# Altium schematic sheet water_quality_sensor_hub.SchDoc(water_quality_sensor_hub)
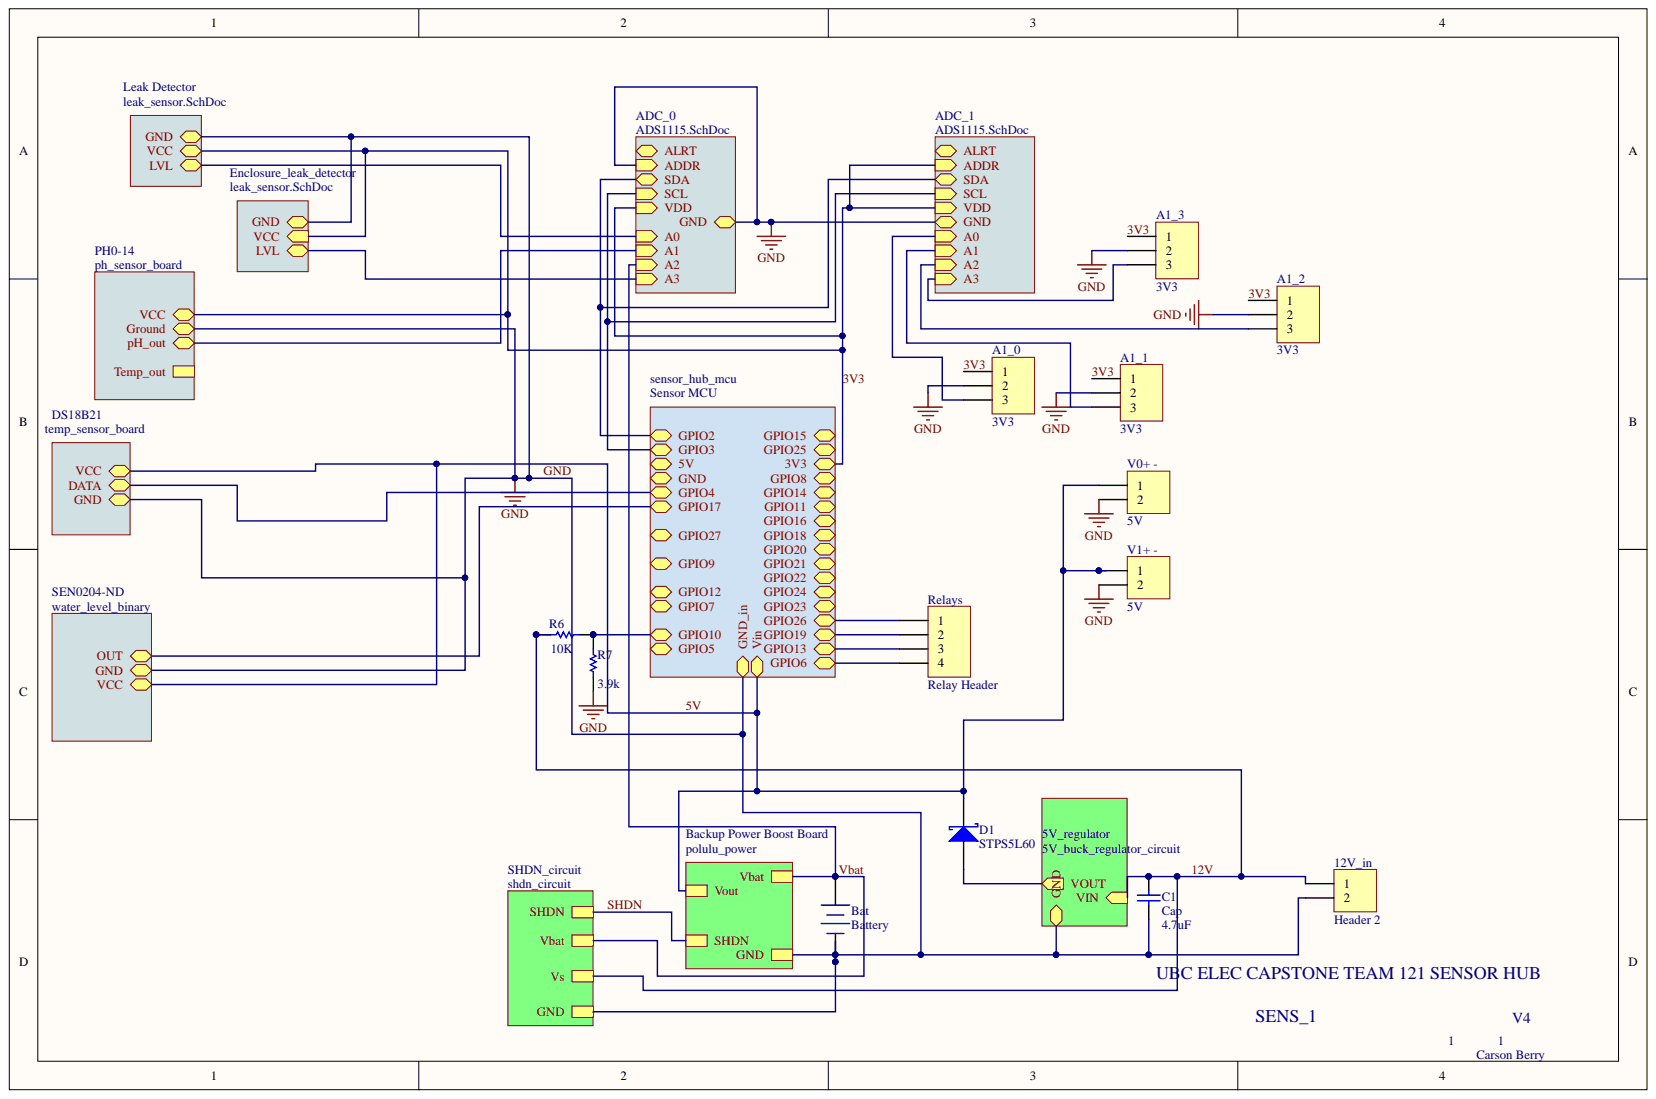
[diagram p0: water_quality_sensor_hub.SchDoc]

## Parts
12V_in  Header 2  [2_channel_terminal_block]
A1_0  3V3  [3_channel_terminal_block]
A1_1  3V3  [3_channel_terminal_block]
A1_2  3V3  [3_channel_terminal_block]
A1_3  3V3  [3_channel_terminal_block]
Bat  Battery  [BAT-2]
C1  Cap  value 4.7uF  [RAD-0.3]
D1  STPS5L60  [STM-DO-201AD]
R6  value 10K  [AXIAL-0.3]  (hidden comment: Res1)
R7  value 3.9k  [AXIAL-0.3]  (hidden comment: Res1)
Relays  Relay Header  [4_channel_terminal_block]
V0+_-  5V  [2_channel_terminal_block]
V1+_-  5V  [2_channel_terminal_block]

## Nets
3V3: A1_0.1 A1_1.1 A1_2.1 A1_3.1
5V: D1.1 V0+_-.1 V1+_-.1
12V: 12V_in.1 C1.2 R6.1
GND: 12V_in.2 A1_0.2 A1_1.2 A1_2.2 A1_3.2 Bat.2 C1.1 R7.1 V0+_-.2 V1+_-.2
Vbat: Bat.1
NetA1_0_3: A1_0.3
NetA1_1_3: A1_1.3
NetA1_2_3: A1_2.3
NetA1_3_3: A1_3.3
NetD1_2: D1.2
NetR6_2: R6.2 R7.2
NetRelays_1: Relays.1
NetRelays_2: Relays.2
NetRelays_3: Relays.3
NetRelays_4: Relays.4
A0:
A1:
A3:
ALRT:
DATA:
GPIO5:
GPIO7:
GPIO8:
GPIO9:
GPIO11:
GPIO12:
GPIO14:
GPIO15:
GPIO16:
GPIO18:
GPIO20:
GPIO21:
GPIO22:
GPIO23:
GPIO24:
GPIO25:
GPIO27:
OUT:
SCL:
SDA:
SHDN:
Temp_out:

## Library data of the parts
12V_in = Header 2: Power 12V in; Signal Integrity: Connector; Library Name: Miscellaneous Connectors.IntLib; LatestRevisionNote: Re-released for DXP Platform.; LatestRevisionDate: 17-Jul-2002; Component Kind: Standard; Publisher: Altium Limited
A1_0-A1_3 = Header 3: Header, 3-Pin; Signal Integrity: Connector; Library Name: Miscellaneous Connectors.IntLib; LatestRevisionNote: Re-released for DXP Platform.; LatestRevisionDate: 17-Jul-2002; Component Kind: Standard; Publisher: Altium Limited
Bat = Battery: 2AA batteries; Published: 24-Mar-1999; PackageReference: BAT-2; PackageDescription: Battery; 2 Leads; Library Name: Miscellaneous Devices.IntLib; LatestRevisionNote: Re-released for DXP Platform.; LatestRevisionDate: 17-Jul-2002; Component Kind: Standard; Publisher: Altium Limited
C1 = Cap: Capacitor; Simulation: CAP; Signal Integrity: Cap; Published: 8-Jun-2000; PackageReference: RAD-0.3; PackageDescription: Radial Cap, Thru-Hole; 2 Leads; 0.3 in Pin Spacing; Library Name: Miscellaneous Devices.IntLib; LatestRevisionNote: Re-released for DXP Platform.; LatestRevisionDate: 17-Jul-2002; Component Kind: Standard; Publisher: Altium Limited
D1 = CMP-2000-05365-1: Power Schottky rectifier, 60 V, 5 A, 2-Pin DO-201AD, RoHS, Cut Tape; Manufacturer: STMicroelectronics; Voltage - Forward (Vf) (Max) @ If: 520mV@5A; Voltage - DC Reverse (Vr) (Max): 60V; Speed: FastRecovery=200mA(Io); Other Related Documents: STPS5L60ViewAllSpecifications; Other Names: 497-12673-3STPS5L60-ND; Online Catalog: SchottkyDiode; Current - Reverse Leakage @ Vr: 220µA@60V; Current - Average Rectified (Io): 5A; Supplier Device Package: DO-201AD; Standard Package: 600; Series: -; Reverse Recovery Time (trr): -; Packaging: Tape&Box(TB); Package URL: http://download.siliconexpert.com/pdfs/2012/8/10/13/5/5/781/st_/manual/cd00002924.pdf; Package Reference: DO-201AD; Package Description: 2-Pin Axial Lead, Dia 5.3 mm, Body Length 9.5 mm; Package / Case: DO-201AD,Axial; Operating Temperature - Junction: 150degC(Max); Mounting Type: ThroughHole; Manufacturer URL: http://www.st.com/; Library Name: Altium Content Vault; Family: Diodes,Rectifiers-Single; Diode Type: Schottky; Datasheet Version: Rev. 3, 05/2008; Datasheet URL: http://download.siliconexpert.com/pdfs/2012/8/10/13/5/5/781/st_/manual/cd00002924.pdf; Component Kind: Standard; Category: DiscreteSemiconductorProducts; Capacitance @ Vr, F: -
R6 R7 = Res1: Resistor; Simulation: RESISTOR; Signal Integrity: Res; Published: 8-Jun-2000; PackageReference: AXIAL-0.3; PackageDescription: Axial Device, Thru-Hole; 2 Leads; 0.3 in Pin Spacing; Library Name: Miscellaneous Devices.IntLib; LatestRevisionNote: Re-released for DXP Platform.; LatestRevisionDate: 17-Jul-2002; Component Kind: Standard; Publisher: Altium Limited
Relays = Header 4: Relay Control; Signal Integrity: Connector; Library Name: Miscellaneous Connectors.IntLib; LatestRevisionNote: Re-released for DXP Platform.; LatestRevisionDate: 17-Jul-2002; Component Kind: Standard; Publisher: Altium Limited
V0+_- V1+_- = Header 2: Header, 2-Pin; Signal Integrity: Connector; Library Name: Miscellaneous Connectors.IntLib; LatestRevisionNote: Re-released for DXP Platform.; LatestRevisionDate: 17-Jul-2002; Component Kind: Standard; Publisher: Altium Limited
